# Altium schematic sheet Input.SchDoc
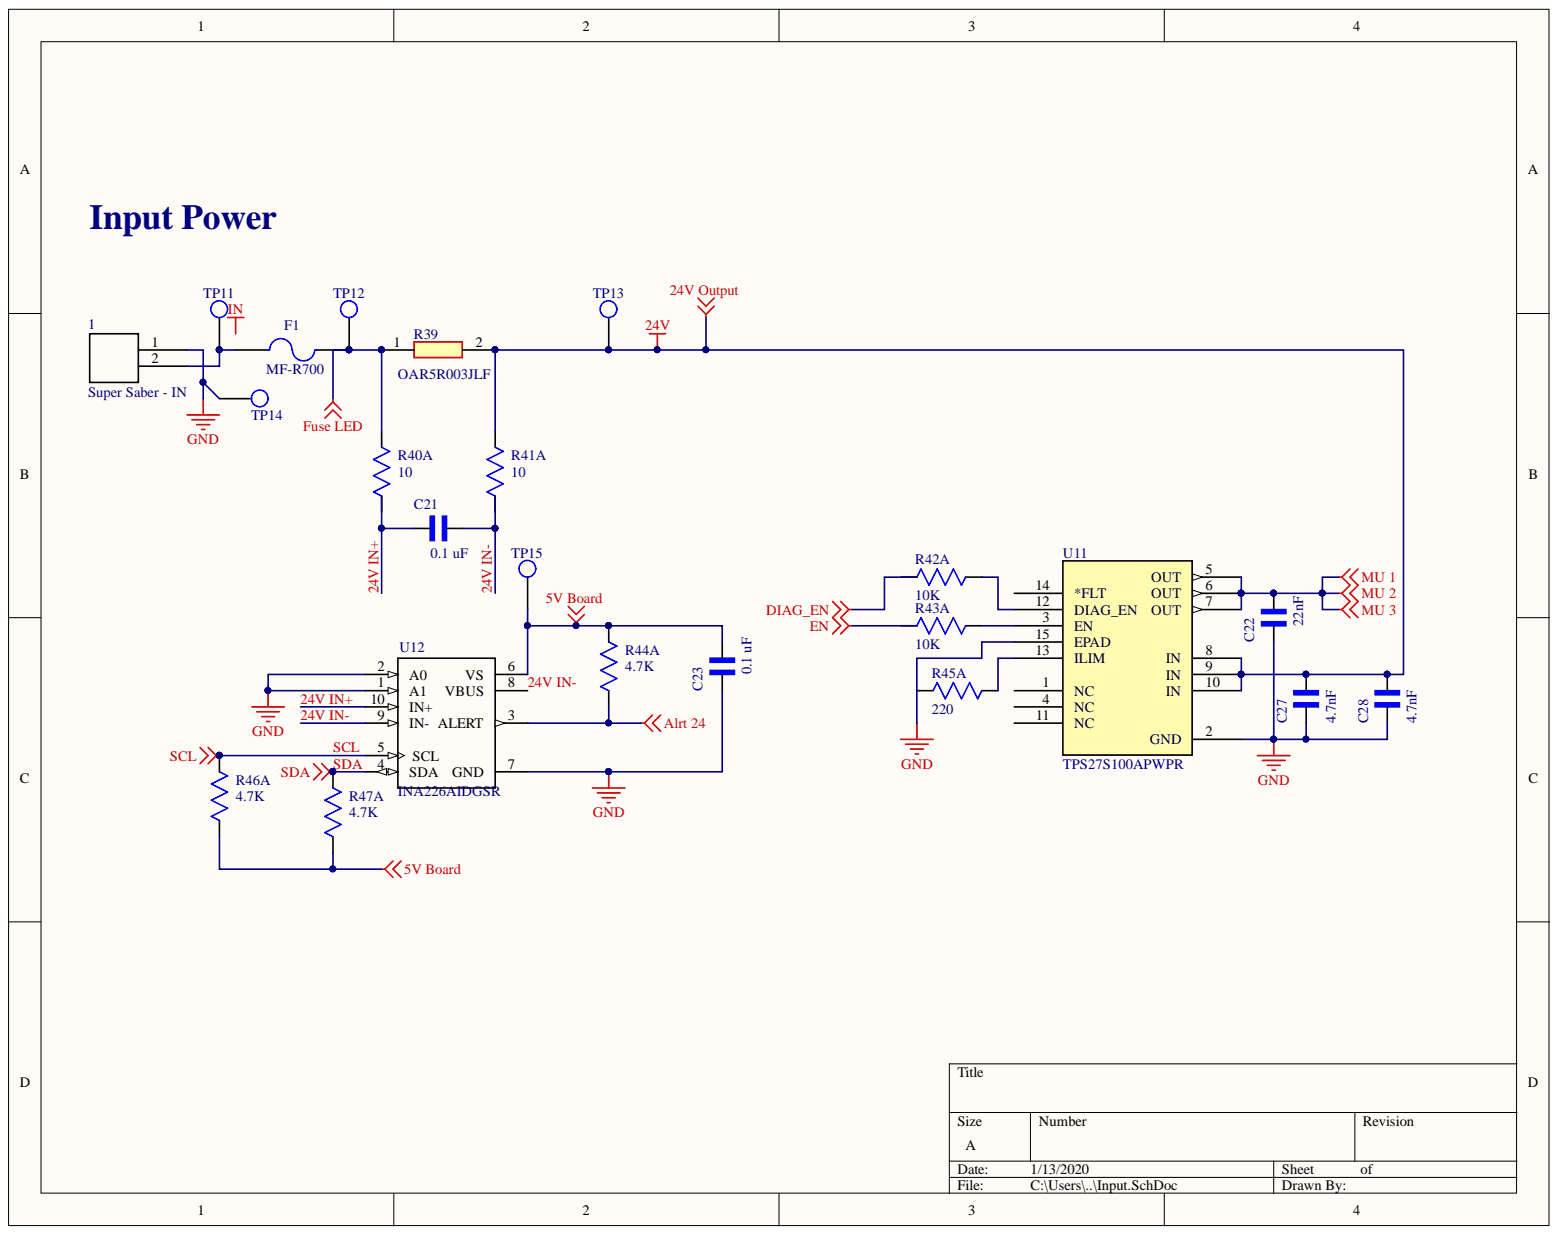
[diagram p0: Input.SchDoc]

## Parts
1  Super Saber - IN  [0431600102]
C21  0.1 uF  [*CAPC2012X135N]
C22  22nF  [*CAPC2012X135N]
C23  0.1 uF  [*CAPC2012X135N]
C27  4.7nF  [*CAPC2012X135N]
C28  4.7nF  [*CAPC2012X135N]
F1  MF-R700  [*2920_FUSE]
R39  OAR5R003JLF  [OAR5R003JLF]
R40  10  [RC0805N]  (part A)
R41  10  [RC0805N]  (part A)
R42  10K  [RC0805N]  (part A)
R43  10K  [RC0805N]  (part A)
R44  4.7K  [RC0805N]  (part A)
R45  220  [RC0805N]  (part A)
R46  4.7K  [RC0805N]  (part A)
R47  4.7K  [RC0805N]  (part A)
TP11  Test Point  [Test Point Through-hole]  (value not drawn)
TP12  Test Point  [Test Point Through-hole]  (value not drawn)
TP13  Test Point  [Test Point Through-hole]  (value not drawn)
TP14  Test Point  [Test Point Through-hole]  (value not drawn)
TP15  Test Point  [Test Point Through-hole]  (value not drawn)
U11  TPS27S100APWPR  [PWP14-M]
U12  INA226AIDGSR  [*SOP50P490X110-10N]

## Nets
5V Board: C23.2 R44.1 TP15.1 U12.6(VS)
24V Output: C27.2 C28.2 R39.2 R41.1 TP13.1 U11.8(IN) U11.9(IN) U11.10(IN)
Alrt 24: U12.3(ALERT)
DIAG_EN: R42.2
EN: R43.2
Fuse LED: F1.2 R39.1 R40.1 TP12.1
GND: 1.1 C22.1 C23.1 C27.1 C28.1 TP14.1 U11.2(GND) U11.15(EPAD) U12.1(A1) U12.2(A0) U12.7(GND)
MU 1: C22.2 U11.5(OUT) U11.6(OUT) U11.7(OUT)
24V IN+: C21.1 R40.2 U12.10(IN+)
24V IN-: C21.2 R41.2 U12.8(VBUS) U12.9(IN-)
SCL: R46.1 U12.5(SCL)
SDA: R47.1 U12.4(SDA)
Net1_2: 1.2 F1.1 TP11.1
NetR42_1: R42.1 U11.12(DIAG_EN)
NetR43_1: R43.1 U11.3(EN)
NetR45_1: R45.1 U11.13(ILIM)
IN:

Not connected: R44 2; R45 2; R46 2; R47 2; U11 1(NC) 4(NC) 11(NC) 14(*FLT)

## Library data of the parts
1 = Super Saber: Component Kind: Standard; Library Name: Connector Schematic Symbols.SchLib
C21-C23 C27 C28 = EMK212BJ225KG-T: Cap Ceramic 2.2uF 16V X5R 10% SMD 0805 125Â°C Embossed T/R; MF: Taiyo Yuden; Component Kind: Standard; Digi-Key_Part_Number: 587-1293-1-ND; Digi-Key_Purchase_URL: https://www.digikey.com/product-detail/en/taiyo-yuden/EMK212BJ225KG-T/587-1293-1-ND/931070?utm_source=snapeda&utm_medium=aggregator&utm_campaign=symbol; Library Name: EMK212BJ225KG-T.SchLib; MP: EMK212BJ225KG-T; Package: 2012 Taiyo Yuden; SnapEDA_Link: https://www.snapeda.com/parts/EMK212BJ225KG-T/Taiyo%20Yuden/view-part/?ref=snap
F1 = Fuse 2: Fuse; Component Kind: Standard; LatestRevisionDate: 17-Jul-2002; LatestRevisionNote: Re-released for DXP Platform.; Library Name: Miscellaneous Devices.IntLib; PackageDescription: Thru-Hole; 2 Leads; PackageReference: PIN-W2/E2.8; Published: 8-Jun-2000; Simulation: FUSE; Publisher: Altium Limited
R39 = OAR5R003JLF: Resistor; Manufacturer_Name: WELWYN; Component Kind: Standard; Datasheet Link: https://componentsearchengine.com/Datasheets/1/OAR5R003JLF.pdf; Height: 22.4mm; Manufacturer_Part_Number: OAR5R003JLF; Mouser Part Number: 66-OAR5R003JLF; Mouser Price/Stock: https://www.mouser.com/Search/Refine.aspx?Keyword=66-OAR5R003JLF
R40-R47 = CRCW0805681RFKEA: No Description Available; Manufacturer_Part_Number: CRCW0805681RFKEA_1; Built_By: EMA_UL_Team; Vendor: Vishay; Component Kind: Standard; Library Name: 2019-11-21_04-54-07.SchLib; RefDes: RefDes; Type: DEV; Value: Value; Copyright: Copyright (C) 2018 Accelerated Designs. All rights reserved
U11 = TPS27S100APWPR: No Description Available; Built_By: EMA_Molly; Vendor: Texas Instruments; Component Kind: Standard; Library Name: Power Switch 2.SchLib; Manufacturer_Part_Number: TPS27S100APWPR; RefDes: RefDes; Type: DEV; Value: Value; Copyright: Copyright (C) 2018 Accelerated Designs. All rights reserved
U12 = INA226AIDGSR: Current Monitor Regulator High/Low-Side 10mA 10-VSSOP; MF: Texas Instruments; Component Kind: Standard; Digi-Key_Part_Number: 296-29034-1-ND; Digi-Key_Purchase_URL: https://www.digikey.com/product-detail/en/texas-instruments/INA226AIDGSR/296-29034-1-ND/2687118?utm_source=snapeda&utm_medium=aggregator&utm_campaign=symbol; Library Name: INA226AIDGSR.SchLib; MP: INA226AIDGSR; Package: MSOP-10 Texas Instruments; SnapEDA_Link: https://www.snapeda.com/parts/INA226AIDGSR/Texas%20Instruments/view-part/53552/?ref=snap
TP11-TP15 = Test Point: Component Kind: Standard; Library Name: Test Points.SchLib
